# Altium schematic sheet MPPT_Controller.SchDoc
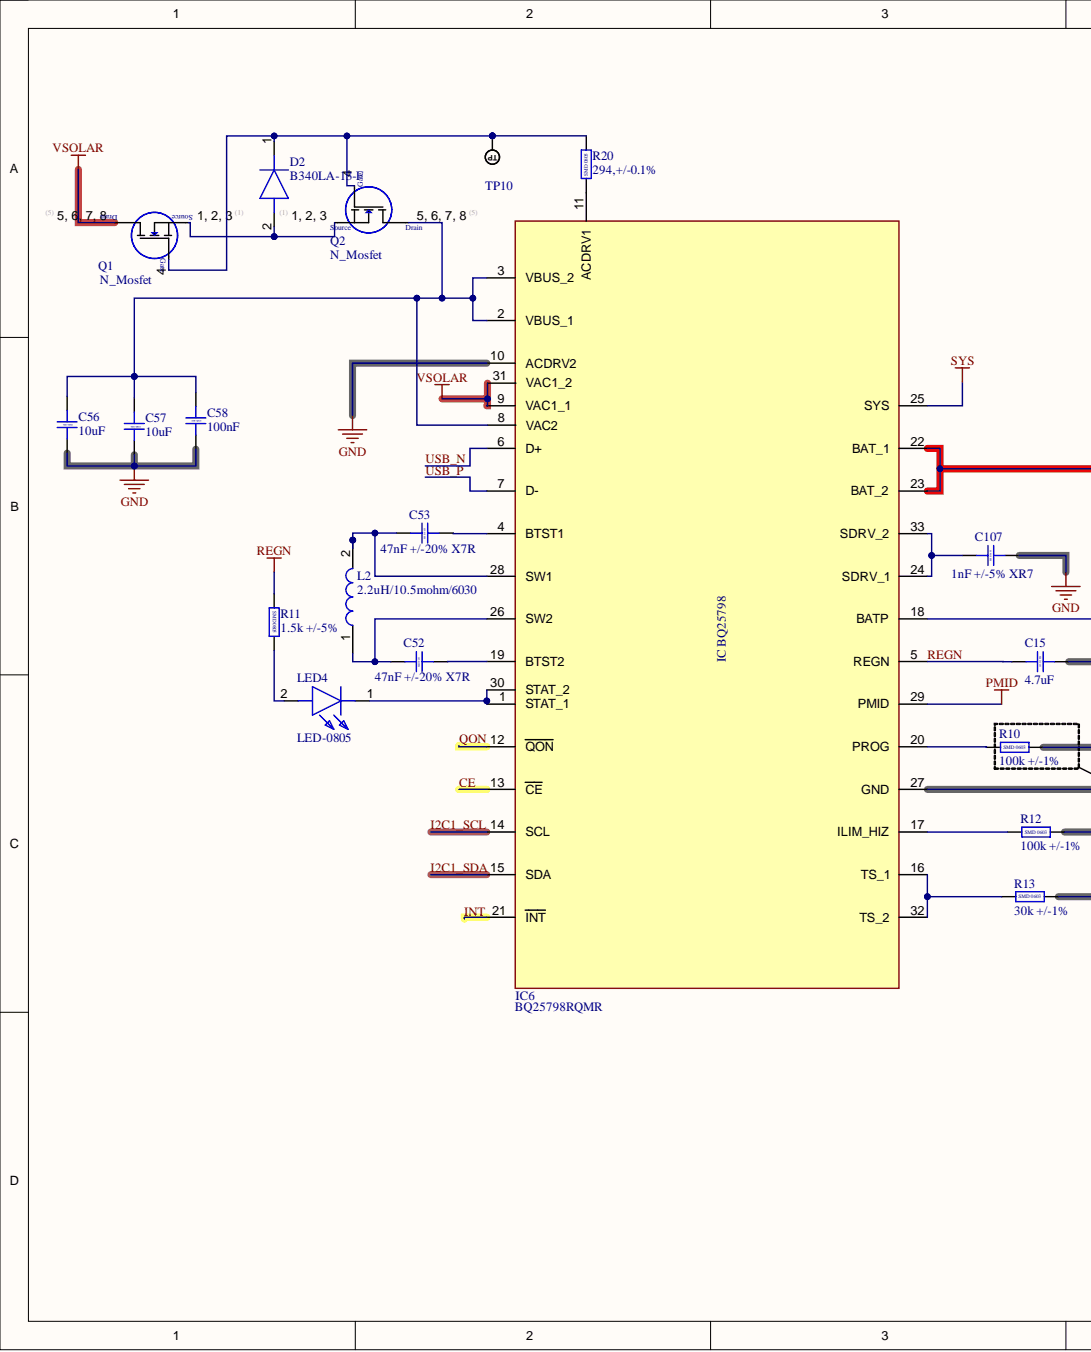
[diagram p0: MPPT_Controller.SchDoc, left]
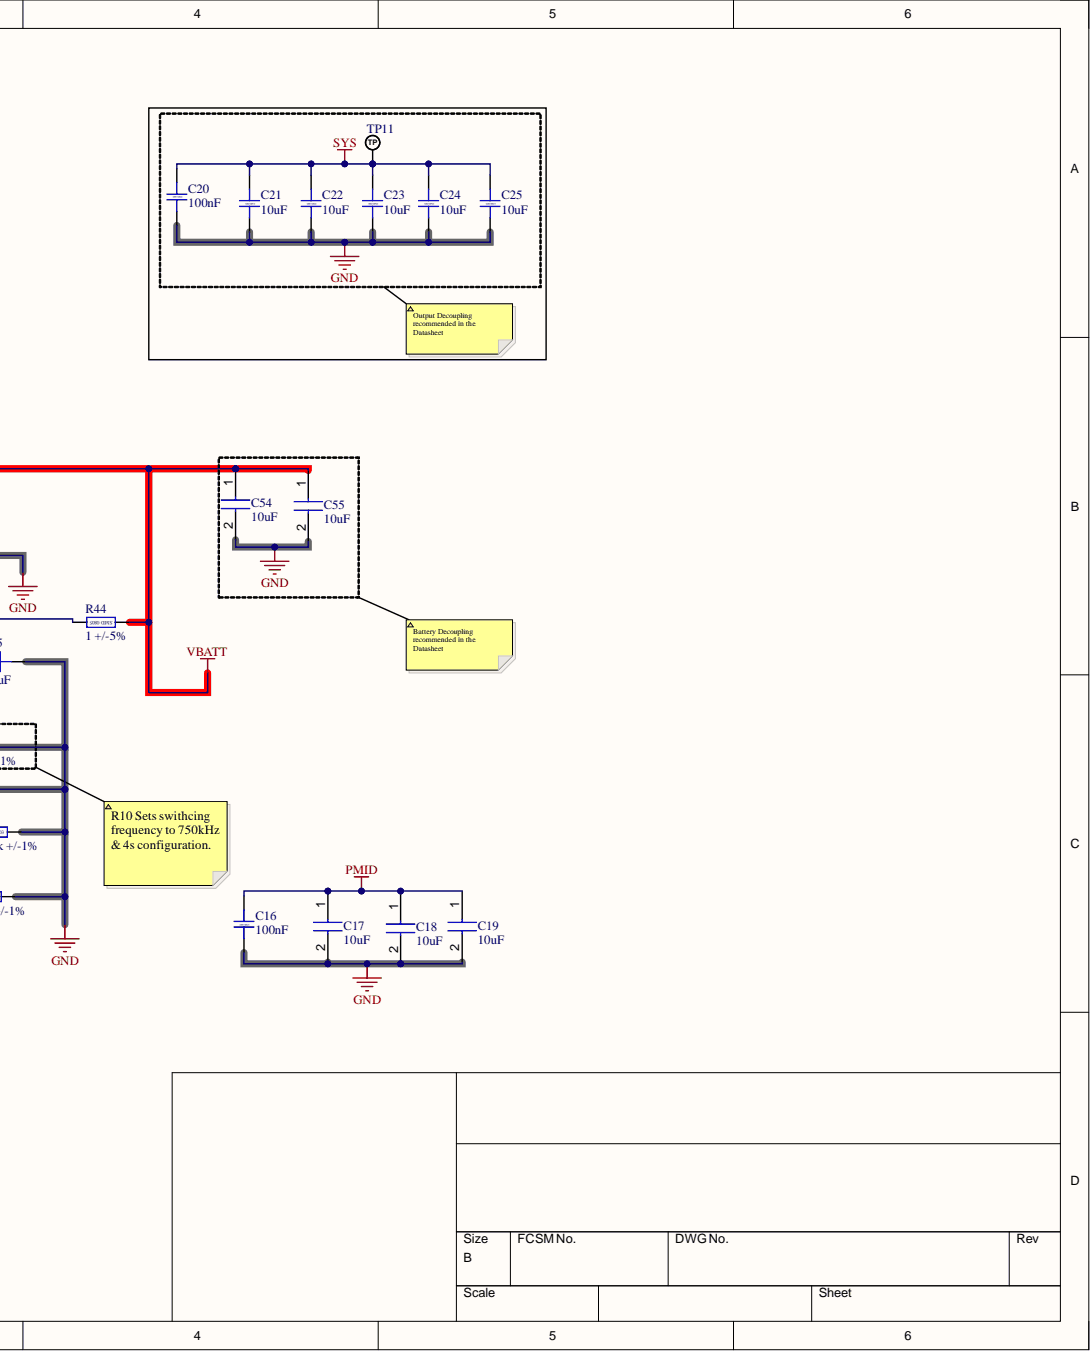
[diagram p1: MPPT_Controller.SchDoc, right]

## Parts
C15  4.7uF  [CAP_0603]
C16  100nF  [CAP_0603]
C17  10uF  [CAP_0805]
C18  10uF  [CAP_0805]
C19  10uF  [CAP_0805]
C20  100nF  [CAP_0603]
C21  10uF  [CAP_0805]
C22  10uF  [CAP_0805]
C23  10uF  [CAP_0805]
C24  10uF  [CAP_0805]
C25  10uF  [CAP_0805]
C52  47nF +/-20% X7R  [CAP_0603]
C53  47nF +/-20% X7R  [CAP_0603]
C54  10uF  [CAP_0805]
C55  10uF  [CAP_0805]
C56  10uF  [CAP_1206]
C57  10uF  [CAP_1206]
C58  100nF  [CAP_0603]
C107  1nF +/-5% XR7  [CAP_0603]
D2  B340LA-13-F  [DIOM5226X240N]
IC6  BQ25798RQMR  [BQ25798RQMR]
L2  2.2uH/10.5mohm/6030  [78439344022]
LED4  LED-0805  [LEDC3216X120N]
Q1  N_Mosfet  [POWERPAK-1212-8]
Q2  N_Mosfet  [POWERPAK-1212-8]
R10  100k +/-1%  [RES_0603]
R11  1.5k +/-5%  [RES_0805]
R12  100k +/-1%  [RES_0603]
R13  30k +/-1%  [RES_0603]
R20  294,+/-0.1%  [RES_0805]
R44  1 +/-5%  [RES_0805]
TP10  TP_NOBOM  [PAD_RND_1MM]  (value not drawn)  (no BOM)
TP11  TP_NOBOM  [PAD_RND_1MM]  (value not drawn)  (no BOM)

## Nets
GND: C15.1 C16.1 C17.2 C18.2 C19.2 C20.1 C21.1 C22.1 C23.1 C24.1 C25.1 C54.2 C55.2 C56.1 C57.1 C58.1 C107.2 IC6.10(ACDRV2) IC6.27(GND) R10.2 R12.2 R13.2
PMID: C16.2 C17.1 C18.1 C19.1 IC6.29(PMID)
SYS: C20.2 C21.2 C22.2 C23.2 C24.2 C25.2 IC6.25(SYS) TP11.1
VBATT: C54.1 C55.1 IC6.22(BAT_1) IC6.23(BAT_2) R44.1
VSOLAR: IC6.9(VAC1_1) IC6.31(VAC1_2) Q1.5
CE: IC6.13(CE)
I2C1_SCL: IC6.14(SCL)
I2C1_SDA: IC6.15(SDA)
INT: IC6.21(INT)
QON: IC6.12(QON)
REGN: C15.2 IC6.5(REGN) R11.1
USB_N: IC6.6(D+)
USB_P: IC6.7(D-)
NetC52_1: C52.1 IC6.26(SW2) L2.1
NetC52_2: C52.2 IC6.19(BTST2)
NetC53_1: C53.1 IC6.28(SW1) L2.2
NetC53_2: C53.2 IC6.4(BTST1)
NetC56_2: C56.2 C57.2 C58.2 IC6.2(VBUS_1) IC6.3(VBUS_2) IC6.8(VAC2) Q2.5
NetC107_1: C107.1 IC6.24(SDRV_1) IC6.33(SDRV_2)
NetD2_1: D2.1 Q1.4 Q2.4 R20.2 TP10.1
NetD2_2: D2.2 Q1.1 Q2.1
NetIC6_1: IC6.1(STAT_1) IC6.30(STAT_2) LED4.1
NetIC6_11: IC6.11(ACDRV1) R20.1
NetIC6_16: IC6.16(TS_1) IC6.32(TS_2) R13.1
NetIC6_17: IC6.17(ILIM_HIZ) R12.1
NetIC6_18: IC6.18(BATP) R44.2
NetIC6_20: IC6.20(PROG) R10.1
NetLED4_2: LED4.2 R11.2

## Library data of the parts
C15 = Capacitor-SMD-0603: GRM188R6YA475KE15D; Component Kind: Standard; Library Name: STeRG-Passives-Standard.SchLib
C16 C20 C58 = Capacitor-SMD-0603: C0603C104K3RACTU; Component Kind: Standard; Library Name: STeRG-Passives-Standard.SchLib
C17-C19 C54 C55 = C0805C106K4PACTU: Capacitor; Manufacturer_Name: KEMET; Arrow Part Number: C0805C106K4PACTU; Arrow Price/Stock: https://www.arrow.com/en/products/c0805c106k4pactu/kemet-corporation; Component Kind: Standard; Datasheet Link: https://content.kemet.com/datasheets/KEM_C1006_X5R_SMD.pdf; Height: 1.1mm; Manufacturer_Part_Number: C0805C106K4PACTU; Mouser Part Number: 80-C0805C106K4P; Mouser Price/Stock: https://www.mouser.co.uk/ProductDetail/KEMET/C0805C106K4PACTU?qs=LOQsJ2JwiRQzQlGR%2F0Z13g%3D%3D
C21-C25 = Capacitor-SMD-0805: C0805C106K4PACTU; Component Kind: Standard; Library Name: STeRG-Passives-Standard.SchLib
C52 C53 = Capacitor-SMD-0603: C0603C473M4RACTU; Component Kind: Standard; Library Name: STeRG-Passives-Standard.SchLib
C56 C57 = Capacitor-SMD-1206: C1206C106K4RACTU; Component Kind: Standard; Library Name: STeRG-Passives-Standard.SchLib
C107 = Capacitor-SMD-0603: Capacitor SMD 0603 RATED; Component Kind: Standard; Library Name: STeRG-Passives-Standard.SchLib
D2 = B340LA-13-F: Diode; Manufacturer_Name: Diodes Incorporated; Arrow Part Number: B340LA-13-F; Arrow Price/Stock: https://www.arrow.com/en/products/b340la-13-f/diodes-incorporated?utm_currency=USD&region=nac; Component Kind: Standard; Datasheet Link: http://www.mouser.com/ds/2/115/ds30240-71143.pdf; Height: 2.4mm; Library Name: SamacSys.SchLib; Manufacturer_Part_Number: B340LA-13-F; Mouser Part Number: 621-B340LA-F; Mouser Price/Stock: https://www.mouser.co.uk/ProductDetail/Diodes-Incorporated/B340LA-13-F?qs=dU8xVB810ithG3OzkYQiSg%3D%3D
IC6 = BQ25798RQMR: Integrated Circuit; Manufacturer_Name: Texas Instruments; Arrow Part Number: BQ25798RQMR; Arrow Price/Stock: https://www.arrow.com/en/products/bq25798rqmr/texas-instruments?utm_currency=USD&region=nac; Component Kind: Standard; Datasheet Link: https://www.ti.com/lit/gpn/bq25798; Height: 1mm; Library Name: SamacSys.SchLib; Manufacturer_Part_Number: BQ25798RQMR; Mouser Part Number: 595-BQ25798RQMR; Mouser Price/Stock: https://www.mouser.co.uk/ProductDetail/Texas-Instruments/BQ25798RQMR?qs=ljCeji4nMDmr66dwAbNsCg%3D%3D
L2 = 78439344022: Inductor; Manufacturer_Name: Wurth Elektronik; Component Kind: Standard; Datasheet Link: https://www.we-online.com/components/products/download/3D_WE-XHMA-6030_78439344022+%28rev1%29.pdf; Height: 3.3mm; Manufacturer_Part_Number: 78439344022; Mouser Part Number: 710-78439344022; Mouser Price/Stock: https://www.mouser.co.uk/ProductDetail/Wurth-Elektronik/78439344022?qs=Znm5pLBrcAJzYzhgJXsY%252Bg%3D%3D
LED4 = LED-0805: KPT-2012ZGC; Manufacturer_Name: Lite-On; Manufacturer_Part_Number: LTST-C230KFKT; Arrow Part Number: LTST-C230KFKT; Arrow Price/Stock: https://www.arrow.com/en/products/ltst-c230kfkt/lite-on-technology?region=nac; Component Kind: Standard; Datasheet Link: https://media.digikey.com/pdf/Data%20Sheets/Lite-On%20PDFs/LTST-C230KFKT_5-24-06.pdf; Height: 1.2mm; Mouser Part Number: 859-LTST-C230KFKT; Mouser Price/Stock: https://www.mouser.co.uk/ProductDetail/Lite-On/LTST-C230KFKT?qs=8myqPtD7QBPZHIbUaocfpw%3D%3D; Library Name: STeRG-Passives-Standard.SchLib
Q1 Q2 = N_Mosfet: SIS412DN-T1-GE3; Component Kind: Standard; Library Name: Header_SchematicLibrary.SchLib
R10 R12 = Resistor-SMD-0603: RC0603FR-13100KL; Component Kind: Standard; Library Name: STeRG-Passives-Standard.SchLib
R11 R44 = Resistor-SMD-0805: ERJUP6J101V; Component Kind: Standard; Library Name: STeRG-Passives-Standard.SchLib
R13 = Resistor-SMD-0603: RC0603FR-1330KL; Component Kind: Standard; Library Name: STeRG-Passives-Standard.SchLib
R20 = Resistor-SMD-0805: MCWF08R2940BTL; Component Kind: Standard; Library Name: STeRG-Passives-Standard.SchLib
TP10 TP11 = TEST_POINT_RND_1MM: Test Point Round 1mm (NO BOM); Library Name: STeRG-Passives-Standard.SchLib
